# Altium schematic sheet ADC.SchDoc
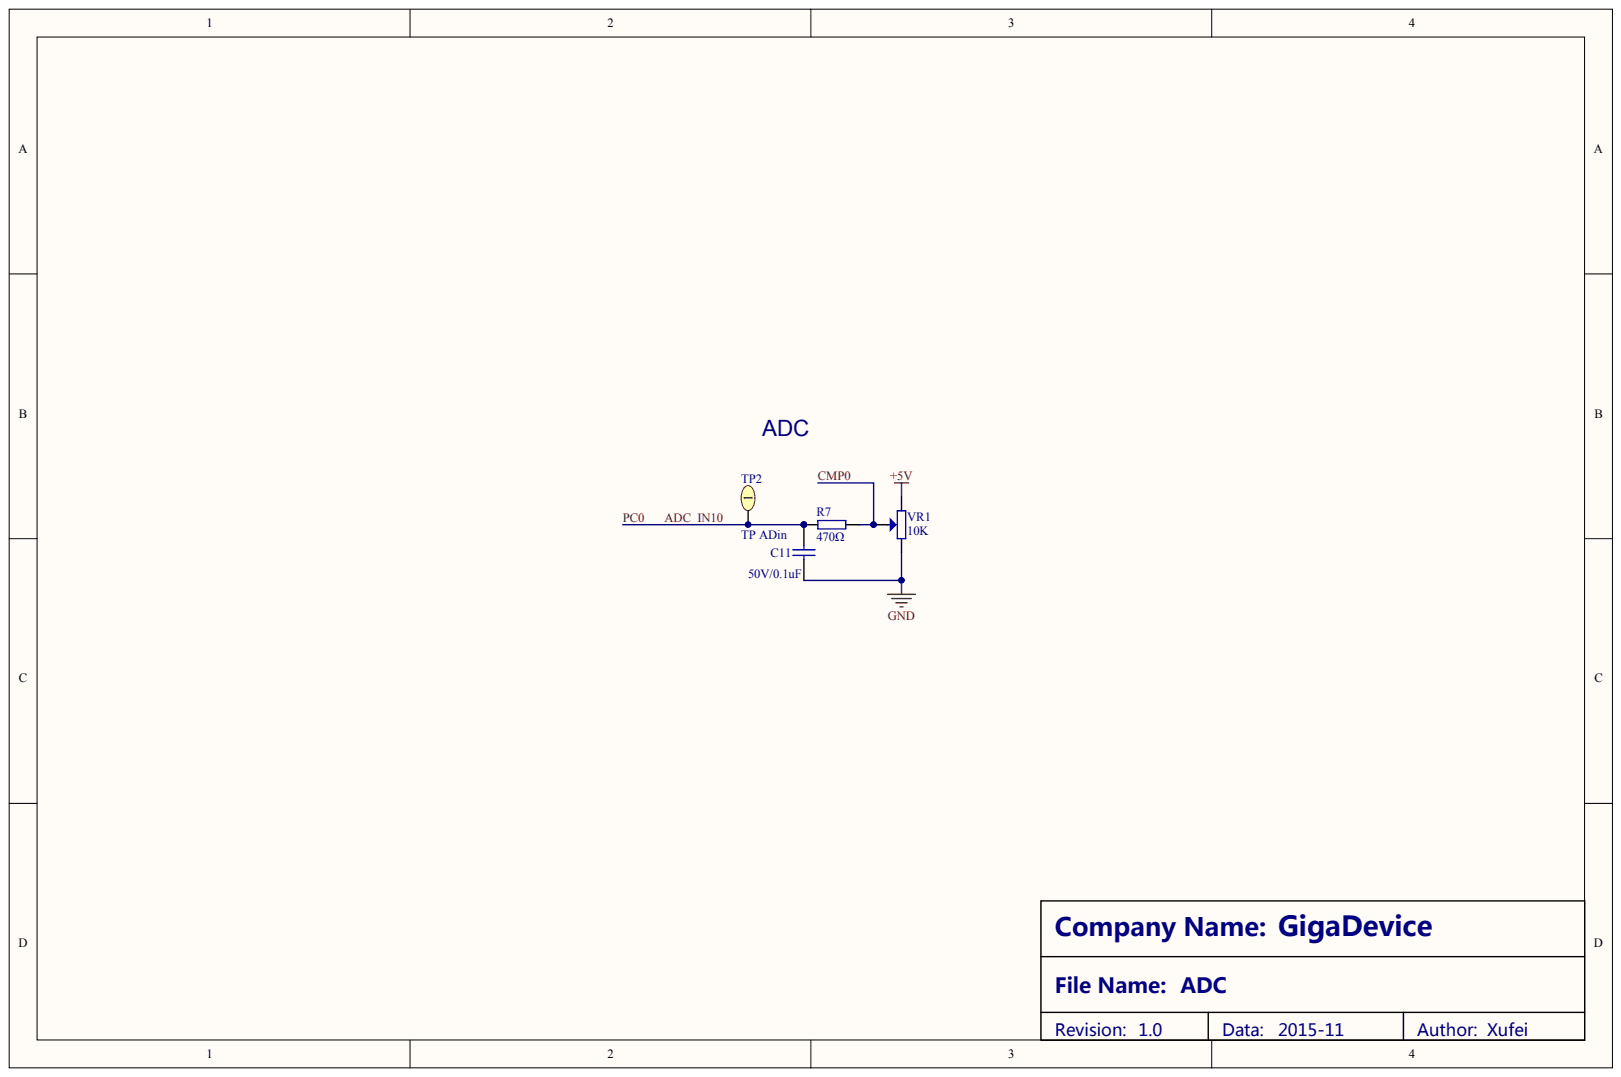
[diagram p0: ADC.SchDoc]

## Parts
C11  50V/0.1uF
R7  470Ω
TP2  TP ADin
VR1  10K

## Nets
+5V: VR1.3
GND: C11.1 VR1.1
ADC_IN10: C11.2 R7.1 TP2.1
CMP0: R7.2 VR1.2
